# Altium schematic sheet AFE_CH1.SchDoc(AFE_CH1)
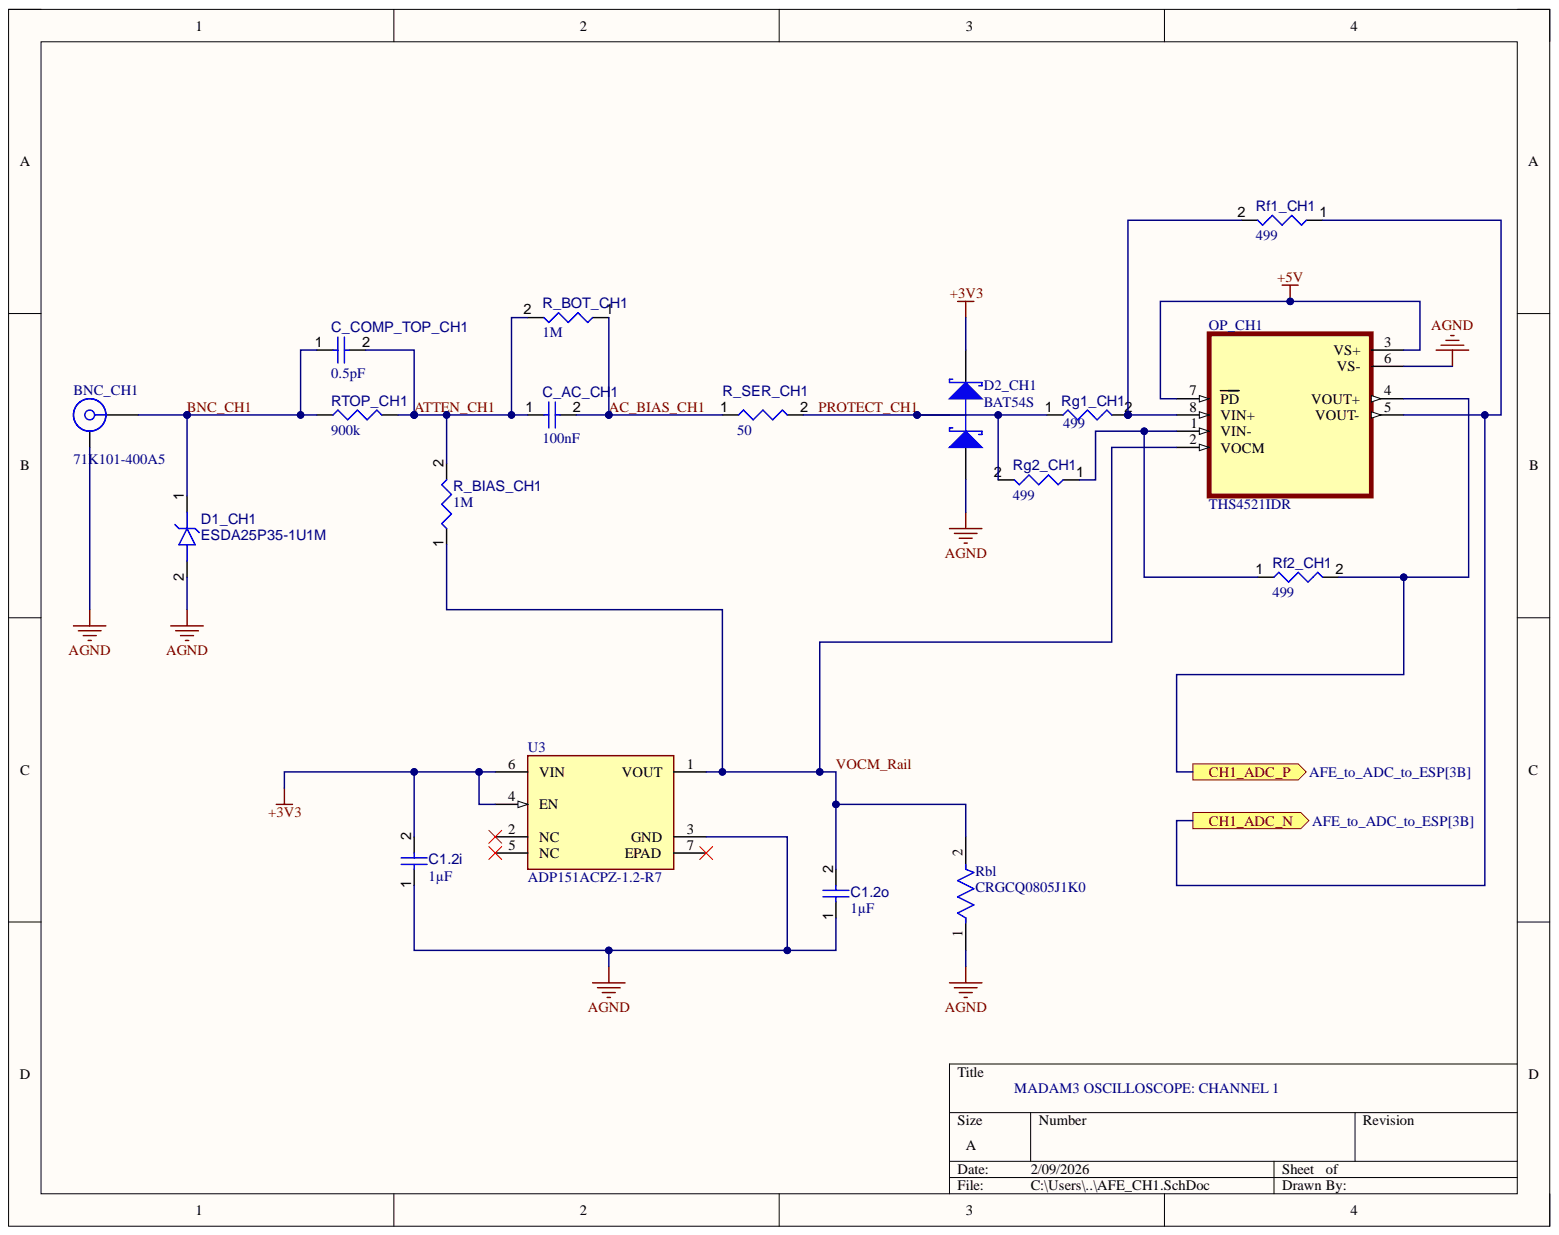
[diagram p0: AFE_CH1.SchDoc]

## Parts
BNC_CH1  71K101-400A5  [ROS-71K101-400A5_V]
C1.2i  value 1µF  [CAPC1608X94X35NL15T15]  (hidden comment: Capacitor 1 uF +/- 10% 16 V 0603)
C1.2o  value 1µF  [CAPC1608X94X35NL15T15]  (hidden comment: Capacitor 1 uF +/- 10% 16 V 0603)
C_AC_CH1  value 100nF  [CAPC1608X90X40NL10T20]  (hidden comment: Capacitor 100 nF +/-10% 50 V 0603)
C_COMP_TOP_CH1  value 0.5pF  [CAPC1005X56X25ML10T15]  (hidden comment: Capacitor 15 pF +/- 0.1pF 25 V 0402)
D1_CH1  ESDA25P35-1U1M  [STM-QFN1610]
D2_CH1  BAT54S  [FAIR-SOT23-3_V]
OP_CH1  THS4521IDR  [SOIC127P599X175-8N]
R_BIAS_CH1  value 1M  [RESC0402(1005)_L]  (hidden comment: Resistor 1M +/-1 % 0402 63 mW)
R_BOT_CH1  value 1M  [RESC0402(1005)_L]  (hidden comment: Resistor 100K +/-1 % 0402 63 mW)
R_SER_CH1  value 50  [RESC0402(1005)_L]  (hidden comment: Resistor 1M +/-1 % 0402 63 mW)
Rbl  CRGCQ0805J1K0  [FP-CRGCQ0805-IPC_C]
Rf1_CH1  value 499  [RESC1005X40X25ML05T05]  (hidden comment: Resistor 1k +/-1% 0402 63 mW)
Rf2_CH1  value 499  [RESC1005X40X25ML05T05]  (hidden comment: Resistor 1k +/-1% 0402 63 mW)
Rg1_CH1  value 499  [RESC1005X40X25ML05T05]  (hidden comment: Resistor 1k +/-1% 0402 63 mW)
Rg2_CH1  value 499  [RESC1005X40X25ML05T05]  (hidden comment: Resistor 1k +/-1% 0402 63 mW)
RTOP_CH1  value 900k  [RESC0402(1005)_L]  (hidden comment: Resistor 1M +/-1 % 0402 63 mW)
U3  ADP151ACPZ-1.2-R7  [FP-CP-6-3-IPC_A]

## Ports
CH1_ADC_N, CH1_ADC_P

## Nets
+3V3: C1.2i.2 D2_CH1.2 U3.4(EN) U3.6(VIN)
+5V: OP_CH1.3(VS+) OP_CH1.7(PD)
AGND: BNC_CH1.MH C1.2i.1 C1.2o.1 D1_CH1.2 D2_CH1.1 OP_CH1.6(VS-) Rbl.1 U3.3(GND)
AC_BIAS_CH1: C_AC_CH1.2 R_BOT_CH1.1 R_SER_CH1.1
ATTEN_CH1: C_AC_CH1.1 C_COMP_TOP_CH1.2 R_BIAS_CH1.2 R_BOT_CH1.2 RTOP_CH1.2
BNC_CH1: BNC_CH1.1 C_COMP_TOP_CH1.1 D1_CH1.1 RTOP_CH1.1
CH1_ADC_N: OP_CH1.5(VOUT-) Rf1_CH1.1 ; port CH1_ADC_N
CH1_ADC_P: OP_CH1.4(VOUT+) Rf2_CH1.2 ; port CH1_ADC_P
PROTECT_CH1: D2_CH1.3 R_SER_CH1.2 Rg1_CH1.1 Rg2_CH1.2
VOCM_Rail: C1.2o.2 OP_CH1.2(VOCM) R_BIAS_CH1.1 Rbl.2 U3.1(VOUT)
NetOP_CH1_1: OP_CH1.1(VIN-) Rf2_CH1.1 Rg2_CH1.1
NetOP_CH1_8: OP_CH1.8(VIN+) Rf1_CH1.2 Rg1_CH1.2

Not connected: U3 2(NC) 5(NC) 7(EPAD)

## Library data of the parts
BNC_CH1 = CMP-0757-00001-1: 75R BNC Straight Jack, Up to 4 GHz, -65 to 165 degC; Manufacturer: Rosenberger; Design Note: Footprint in library is a generic example - only application specific footprint delivers High Performance; Component Kind: Standard; ComponentLink1Description: Manufacturer URL; ComponentLink1URL: http://www.rosenberger.de; ComponentLink2Description: Datasheet; ComponentLink2URL: http://rosenberger.de/ok/images/documents/db/71k101-400A5.pdf; ComponentLink3Description: Package Specification; ComponentLink3URL: http://rosenberger.de/ok/images/documents/db/71K101-400A5.pdf; ComponentLink4Description: Series Overview / Design Note; ComponentLink4URL: http://rosenberger.de/ok/images/documents/spez/71.pdf; ComponentLink5Description: Webshop / RFQ; ComponentLink5URL: http://www.rosenberger.com/en/contact/sales/; DatasheetVersion: a00; Library Name: Altium Content Vault; Mounting Technology: Through Hole; PackageDescription: 75R BNC, Straight Jack, 12 x 12 x 15 mm; PackageReference: 71K101-400A5; PackageVersion: a00; PartNumber: 71K101-400A5
C1.2i C1.2o = CMP-001-00003-6: Chip Capacitor, 1 uF, +/- 10%, 16 V, 0603 (1608 Metric); Capacitor Type: Ceramic; Voltage Rating: 16V; Case/Package: 0603; Component Kind: Standard; Max Operating Temperature: 125°C; Min Operating Temperature: -55°C; Mounting Technology: SM; Pins: 2; RoHS Compliant: True; Tolerance: 10%; Library Name: Amz Amz
C_AC_CH1 = CMP-001-00017-6: Chip Capacitor, 100 nF, +/- 10%, 50 V, 0603 (1608 Metric); Capacitor Type: Ceramic; Voltage Rating: 50V; Case/Package: 0603; Component Kind: Standard; Max Operating Temperature: 125°C; Min Operating Temperature: -55°C; Mounting Technology: SM; Pins: 2; RoHS Compliant: True; Tolerance: 10%; Library Name: Amz Amz
C_COMP_TOP_CH1 = CMP-001-00002-6: Chip Capacitor, 0.5 pF, +/- 0.1pF, 25 V, 0402 (1005 Metric); Capacitor Type: Ceramic; Tolerance: 20%; Voltage Rating: 25V; Case/Package: 0402; Component Kind: Standard; Max Operating Temperature: 125°C; Min Operating Temperature: -55°C; Mounting Technology: SM; Pins: 2; RoHS Compliant: True; Library Name: Amz Amz
D1_CH1 = CMP-004-00023-3: TVS DIODE 22V 41V 1610; Max Surge Current: 35A; Peak Inverse Voltage: 23.3V; Case/Package: QFN1610 (0603); Component Kind: Standard; Mounting Technology: SMT; Pins: 2; RoHS Compliant: Yes; Library Name: Amz Amz
D2_CH1 = CMP-004-00013-2: Schottky Barrier Diode, 30 V, 0.2 A, -55 to 150 degC, 3-Pin SOT23, RoHS, Tape and Reel; Manufacturer: Fairchild; Elements: 2; Forward Current: 200mA; Forward Voltage: 800mV; Max Surge Current: 600mA; Package Version: Rev. 12, 03/2016; Peak Reverse Current: 2uA; Recovery Time: 5ns; Case/Package: SOT-23; Component Kind: Standard; Datasheet URL: https://www.fairchildsemi.com/datasheets/BA/BAT54S.pdf; Datasheet Version: Rev. 2.3, 04/2016; Manufacturer URL: https://www.fairchildsemi.com/; Max Operating Temperature: 150°C; Min Operating Temperature: -55°C; Mounting Technology: SM; Package Description: 3-Pin Small Outline Transistor, Body 2.92 x 1.325 mm, Pitch 0.95 mm; Package Quantity: 3000; Package Reference: SOT23-3; Package URL: http://www.fairchildsemi.com/dwg/MA/MA03D.pdf; Packaging: TapeandReel; Pins: 3; RoHS Compliant: True; Library Name: Amz Amz
OP_CH1 = CMP-008-000000-1: Very low power rail-to-rail output fully differential amplifier; MF: Texas Instruments; Check_prices: https://www.snapeda.com/parts/THS4521IDR/Texas+Instruments/view-part/?ref=eda; Component Kind: Standard; Component Type: Standard; Design Item ID: THS4521IDR; MP: THS4521IDR; Package: SOIC-8 Texas Instruments; Pin Count: 8; Simulation: ANALOG_BUFFER; SnapEDA_Link: https://www.snapeda.com/parts/THS4521IDR/Texas+Instruments/view-part/?ref=snap; Source: THS4521IDR.SchLib; Library Name: Amz Amz
R_BIAS_CH1 R_SER_CH1 RTOP_CH1 = CMP-009-00165-3: Chip Resistor, 1 MOhms, +/-1 %, 63 mW, -55 to 155 degC, 0402; Power: 63mW; Tolerance: 1%; Case/Package: 0402; Component Kind: Standard; Pins: 2; Library Name: Amz Amz
R_BOT_CH1 = CMP-009-00168-3: Chip Resistor, 100 kOhms, +/-1 %, 63 mW, -55 to 155 degC, 0402; Power: 63mW; Tolerance: 1%; Case/Package: 0402; Component Kind: Standard; Max Operating Temperature: 155°C; Min Operating Temperature: -55°C; Pins: 2; Library Name: Amz Amz
Rbl = CMP-03211-006849-1: CRGCQ 0805 1K0 5%; Manufacturer: TE Connectivity; Automotive Grade: Grade 686; Power Rating: 0.125W; Resistance: 1KΩ; Temperature Coefficient: ±100ppm/°C; Tolerance: 0.05; Value: 1KΩ; Voltage Rating: 150V; Ambient Temperature Range High: +70°C; Automotive: Yes; Category: Res; Component Kind: Standard; ComponentLink2Description: Datasheet; ComponentLink2URL: https://www.te.com/commerce/DocumentDelivery/DDEController?Action=showdoc&DocId=Data+Sheet%7F1773204-3%7F1%7Fpdf%7FEnglish%7FENG_DS_1773204-3_1.pdf%7F4-2176341-695; Composition: Thick Film; Device Class L1: Passive Components; Device Class L2: Resistors; Device Class L3: Chip SMD Resistors; Digikey Description: CRGCQ 0805 1K0 5%; Height: 0.65mm; Ipc Land Pattern Name: RESC200125X55; Lead Free: Yes; Library Name: Altium Content Vault; Manufacturer Part Number: CRGCQ0805J1K0; Octopart: https://octopart.com/crgcq0805j1k0-te+connectivity-91018208; Package: CRGCQ0805; RoHS: TRUE; Series: CRGCQ; Temperature: -55°C to +155°C; Temperature Range High: +155°C; Temperature Range Low: -55°C
Rf1_CH1 Rf2_CH1 Rg1_CH1 Rg2_CH1 = CMP-009-00012-5: Chip Resistor, 1 KOhm, +/- 1%, 63 mW, -55 to 155 degC, 0402 (1005 Metric); Power: 63mW; Case/Package: 0402; Component Kind: Standard; Max Operating Temperature: 155°C; Min Operating Temperature: -55°C; Mounting Technology: SM; Pins: 2; RoHS Compliant: True; Tolerance: 1%; Library Name: Amz Amz
U3 = CMP-00026-20155214-1: ADP151ACPZ-1.2-R7 Fixed Positive LDO Regulator, 1.2V, 0.23V Dropout, CMOS, PDSO6; Manufacturer Lifecycle Status: PRODUCTION; Accuracy: 1%; Max Input Voltage: 5.5V; Max Output Current: 200mA; Max Supply Current: 350µA; Min Current Limit: 220mA; Min Input Voltage: 2.2V; Nominal Supply Current: 265µA; Number of Outputs: 1; Power Supply Rejection Ratio (PSRR): 70dB; Quiescent Current: 10µA; Case/Package: LFCSP EP; Component Kind: Standard; Contact Plating: Gold; Lead Free: Contains Lead; Library Name: Altium Content Vault; Lifecycle Status: Production; Max Operating Temperature: 125°C; Min Operating Temperature: -40°C; Mount: Surface Mount; NexarPartId: 20155214; Number of Pins: 6; Number of Regulators: 1; Operating Supply Current: 265µA; Output Current: 200mA; Output Type: Fixed; Output Voltage: 1.2V; Output Voltage Accuracy: 1%; Packaging: Digi-Reel®; Polarity: Positive; RoHS: Compliant; Schedule B: 8542390000, 8542390000
